# Altium schematic sheet MOTORES PWM.SchDoc
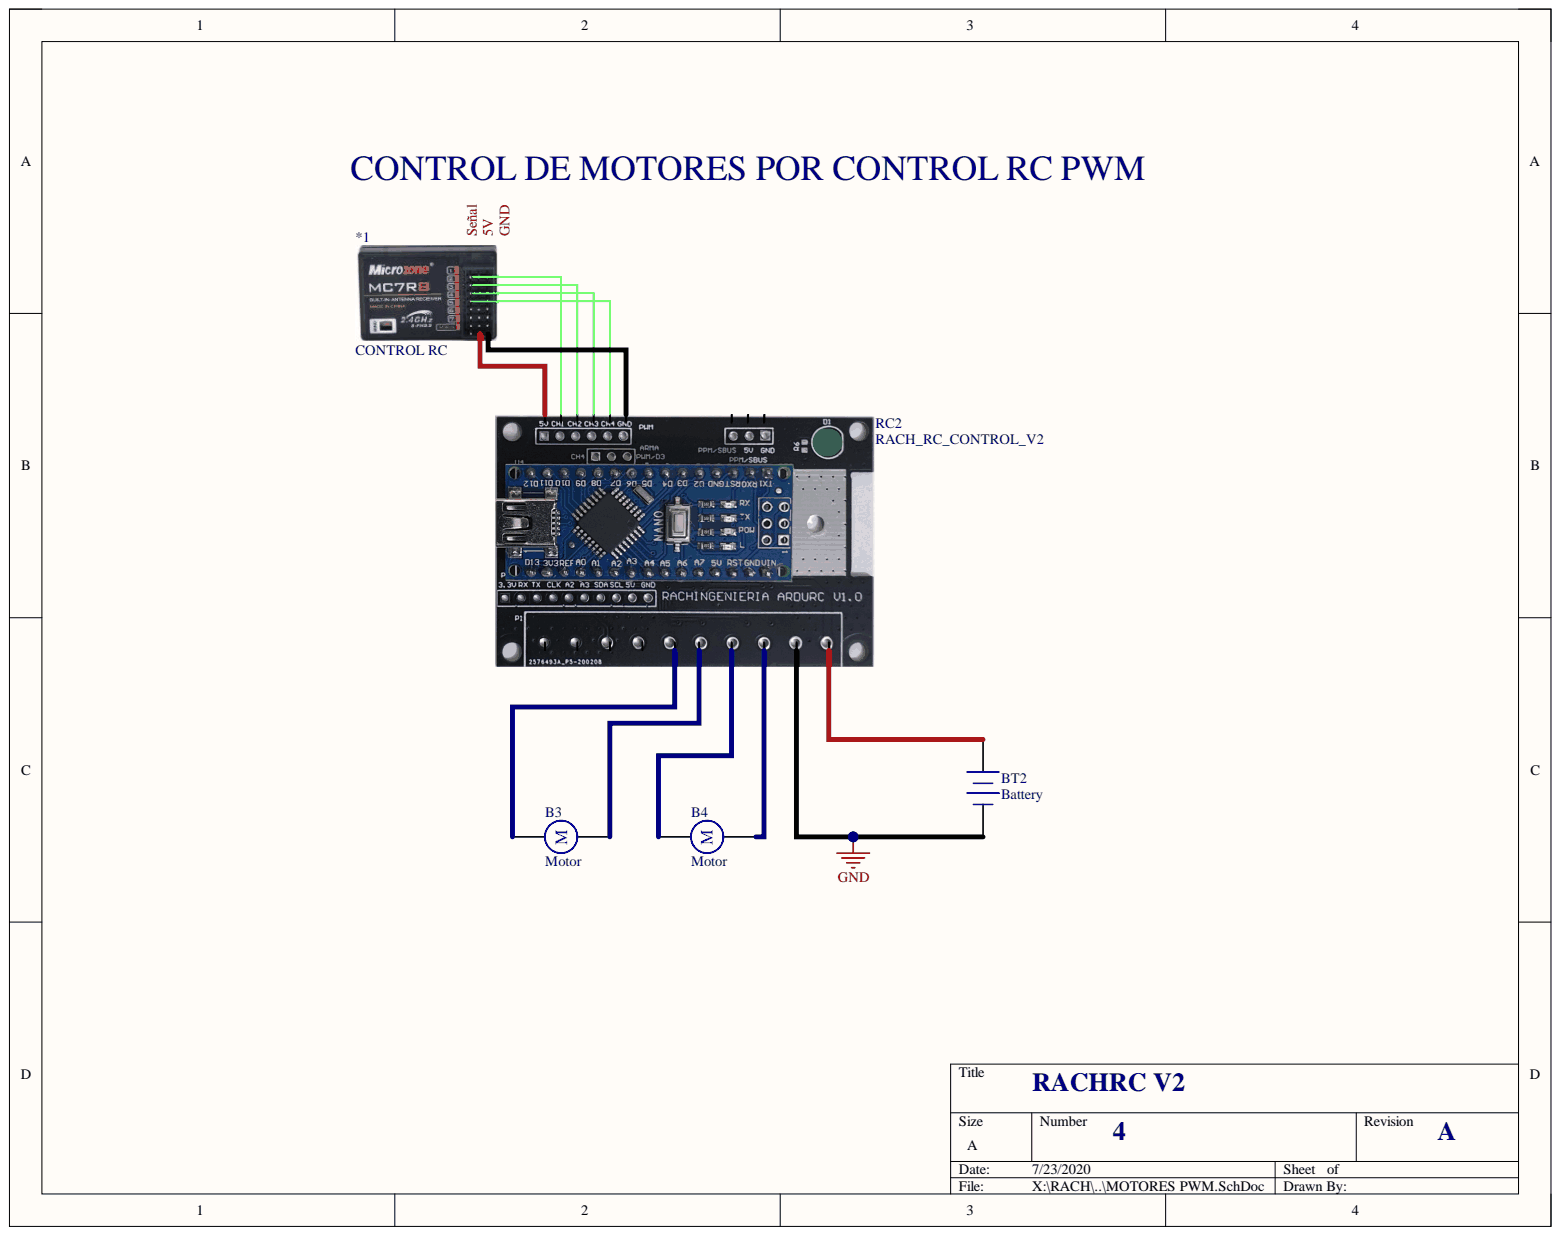
[diagram p0: MOTORES PWM.SchDoc]

## Parts
*1  CONTROL RC
B3  Motor  [RB5-10.5]
B4  Motor  [RB5-10.5]
BT2  Battery  [BAT-2]
RC2  RACH_RC_CONTROL_V2

## Nets
GND: BT2.2 RC2.19
Net*1_1: *1.1 RC2.3
Net*1_4: *1.4 RC2.4
Net*1_7: *1.7 RC2.5
Net*1_10: *1.10 RC2.6
Net*1_23: *1.23 RC2.2
Net*1_24: *1.24 RC2.7
NetB3_1: B3.1 RC2.15
NetB3_2: B3.2 RC2.16
NetB4_1: B4.1 RC2.17
NetB4_2: B4.2 RC2.18
NetBT2_1: BT2.1 RC2.20
5V:
Señal:

Not connected: *1 13 16 19 22; RC2 8 9 10 11 12 13 14

## Library data of the parts
B3 B4 = Motor: Motor, General Kind; Component Kind: Standard; LatestRevisionDate: 17-Jul-2002; LatestRevisionNote: Re-released for DXP Platform.; Library Name: Miscellaneous Devices.IntLib; PackageDescription: Capacitor (Radial); 2 Leads; PackageReference: RB5-10.5; Published: 24-Mar-1999; Publisher: Altium Limited
BT2 = Battery: Multicell Battery; Component Kind: Standard; LatestRevisionDate: 17-Jul-2002; LatestRevisionNote: Re-released for DXP Platform.; Library Name: Miscellaneous Devices.IntLib; PackageDescription: Battery; 2 Leads; PackageReference: BAT-2; Published: 24-Mar-1999; Publisher: Altium Limited
*1 = CONTROL RC: Component Kind: Standard; Library Name: Componentes.SchLib
RC2 = RACH_RC_CONTROL_V2: Component Kind: Standard; Library Name: Componentes.SchLib
